# Altium schematic sheet main.SchDoc
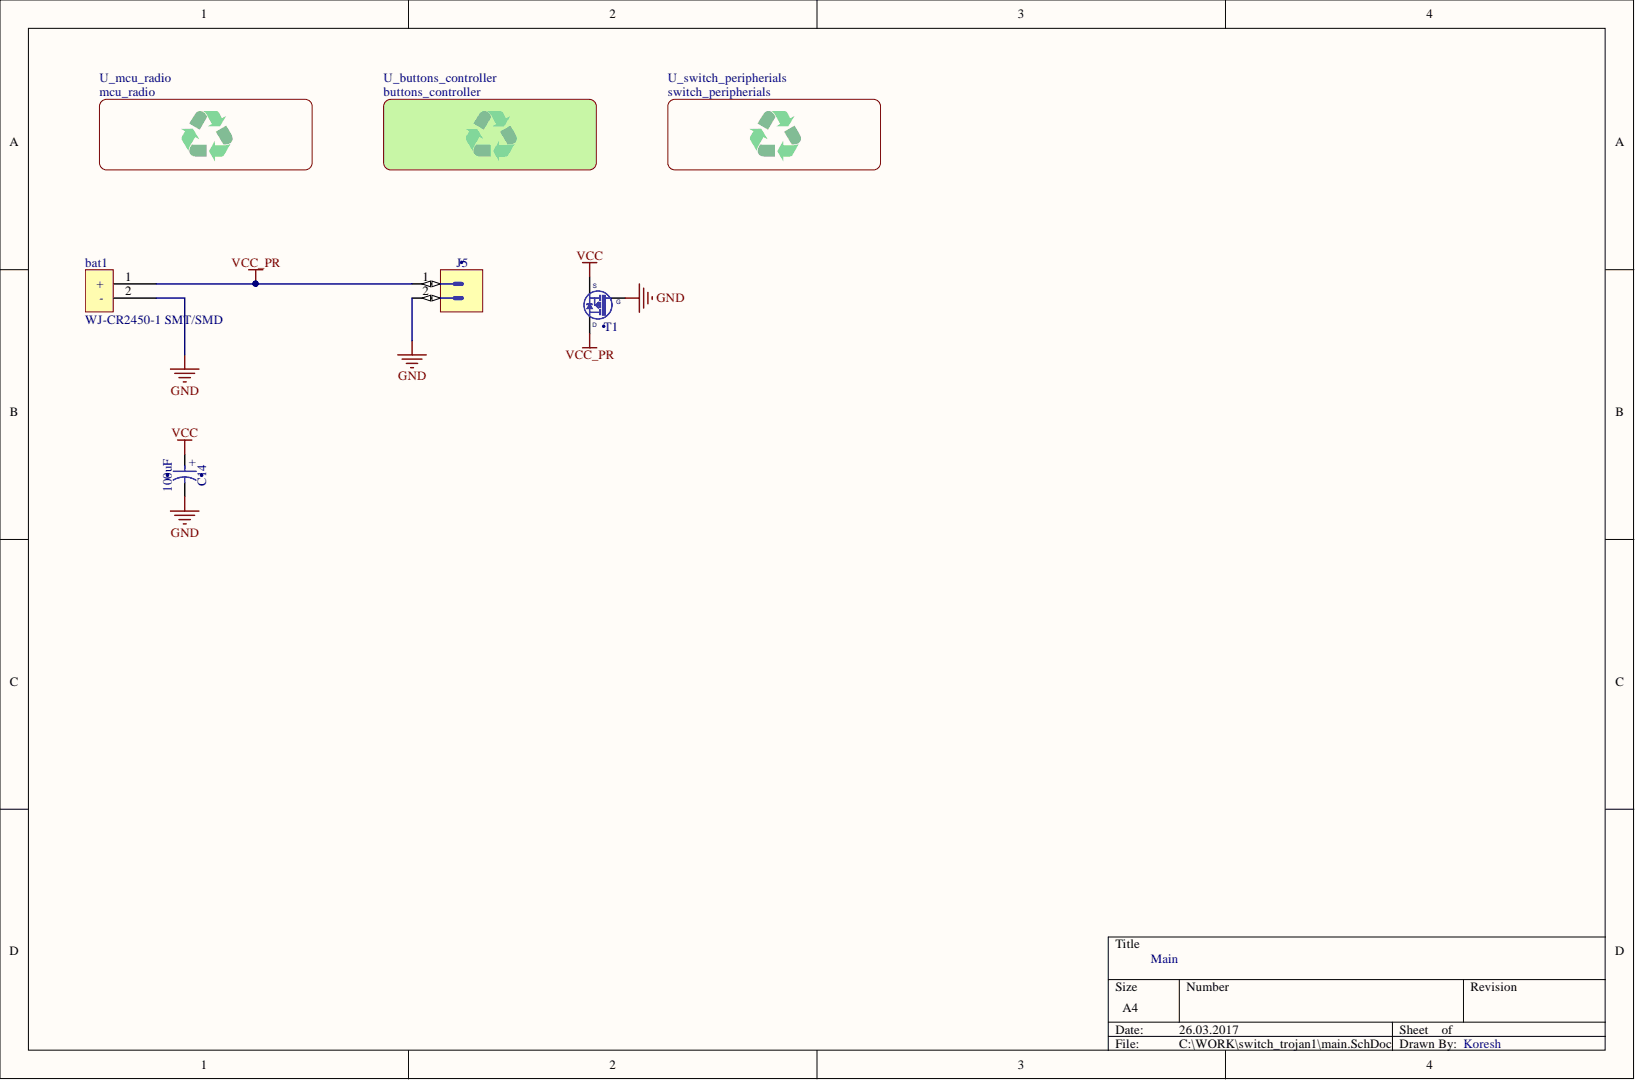
[diagram p0: main.SchDoc]

## Parts
bat1  WJ-CR2450-1 SMT/SMD  [CR2450_1]
C14  100uF  [CAPMP3216X180N]
J5  PicoBlade  [MOLEX_53047-0210]  (value not drawn)
T1  CJ2301  [SOT95P240X110-3N]  (value not drawn)

## Nets
GND: bat1.2 C14.2 J5.2 T1.1
VCC: C14.1 T1.2
VCC_PR: bat1.1 J5.1 T1.3

## Library data of the parts
bat1 = CR2450_1: CR2450  Battery Holder; Component Kind: Standard; Library Name: trojan1.SchLib
C14 = CTE3216-18_10UF_16V_20%_AVX_TCJ: Polarised capacitor; Manufacturer: AVX; Manufacturer Part Number: TCJA106M016R0200; Part Description: ESR 0R200 Tantalum Solid Electrolytic Chip Capacitor with Conductive Polymer Electrode; Database Table Name: Capacitors SMD; Tolerance: ±20%; Voltage: 6V; Case: A; ComponentHeight: 1.8mm; Component Kind: Standard; Component Type: Standard; CreateDate: 29.03.2012; HelpURL: \\cern.ch\dfs\Applications\Altium\Datasheets\CTE_AVX_TCJ.pdf; LatestRevisionDate: 29.03.2012; Library Name: Capacitors.DbLib; Mounted: Yes; Part Number: CTE3216-18_10UF_16V_20%_AVX_TCJ; Pin Count: 2; SMD: Yes; Socket: No; Status: Preferred; Table: Capacitors SMD; Value: 100uF; Author: CERN DEM JLC
J5 = MOLEX_53047-0210: Connector 2 Male, micro JST 1.25mm; Part Description: 1.25mm Pitch PicoBlade™ Wire-to-Board 2 Circuits Vertical Header with Friction Lock; Database Table Name: MOLEX; ComponentHeight: 4.2mm; Component Kind: Standard; Component Type: Standard; CreateDate: 20.03.2013; Family: PicoBlade; HelpURL: \\cern.ch\dfs\Applications\Altium\Datasheets\MOLEX_53047-0210.pdf; LatestRevisionDate: 20.03.2013; Library Name: Connectors.DbLib; Manufacturer: MOLEX; Manufacturer Part Number: 53047-0210; Mounted: Yes; Part Number: MOLEX_53047-0210; Pin Count: 2; SMD: No; Socket: No; Status: None; Table: MOLEX; Author: CERN DEM JLC
T1 = FDN5618P: P-CHANNEL MOSFET WITH DIODE; Manufacturer: FAIRCHILD SEMICONDUCTOR; Part Description: -60V -1.25A P-Channel Logic-Level PowerTrench® MOSFET; Database Table Name: Transistors; Case: SOT23-3; ComponentHeight: 1.12mm; Component Kind: Standard; Component Type: Standard; CreateDate: 19.05.2008; Device: CJ2301; HelpURL: \\cern.ch\dfs\Applications\Altium\Datasheets\FDN5618P.pdf; LatestRevisionDate: 19.05.2008; Library Name: ICs And Semiconductors.DbLib; Manufacturer Part Number: FDN5618P; Mounted: Yes; PackageDescription: SOT23 3-Leads, Pitch 0.95mm - Body 1.4x3mm, IPC Medium Density; Part Number: CJ2301; Pin Count: 3; SMD: Yes; Socket: No; Table: Transistors; Author: CERN DEM JLC
